# Altium schematic sheet Stepper_Outputs.SchDoc
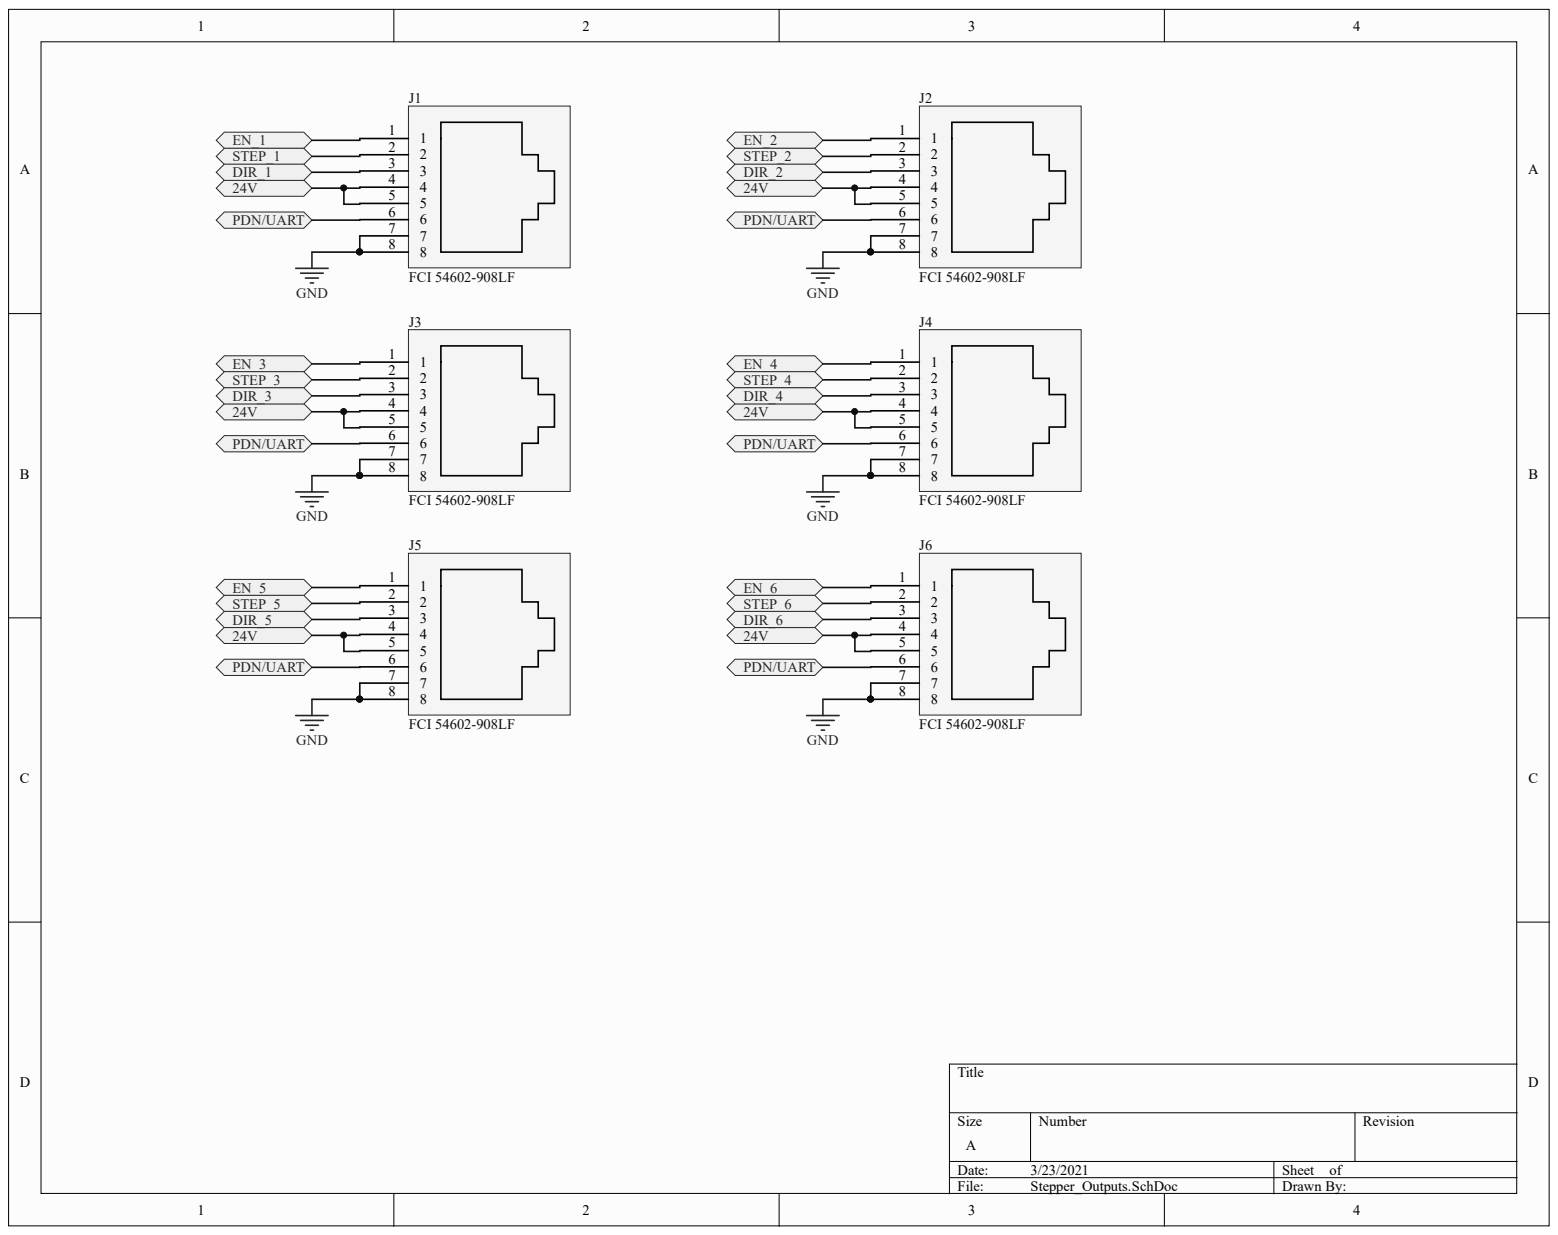
[diagram p0: Stepper_Outputs.SchDoc]

## Parts
J1  FCI 54602-908LF  Manufacturer Part Number: 54602-908LF  [PCB-kyusfhn5h9rxu8er00w0-1]
J2  FCI 54602-908LF  Manufacturer Part Number: 54602-908LF  [PCB-kyusfhn5h9rxu8er00w0-1]
J3  FCI 54602-908LF  Manufacturer Part Number: 54602-908LF  [PCB-kyusfhn5h9rxu8er00w0-1]
J4  FCI 54602-908LF  Manufacturer Part Number: 54602-908LF  [PCB-kyusfhn5h9rxu8er00w0-1]
J5  FCI 54602-908LF  Manufacturer Part Number: 54602-908LF  [PCB-kyusfhn5h9rxu8er00w0-1]
J6  FCI 54602-908LF  Manufacturer Part Number: 54602-908LF  [PCB-kyusfhn5h9rxu8er00w0-1]

## Ports
24V, DIR_1, DIR_2, DIR_3, DIR_4, DIR_5, DIR_6, EN_1, EN_2, EN_3, EN_4, EN_5, EN_6, PDN/UART, STEP_1, STEP_2, STEP_3, STEP_4, STEP_5, STEP_6

## Nets
GND: J1.7 J1.8 J2.7 J2.8 J3.7 J3.8 J4.7 J4.8 J5.7 J5.8 J6.7 J6.8
24V: J1.4 J1.5 J2.4 J2.5 J3.4 J3.5 J4.4 J4.5 J5.4 J5.5 J6.4 J6.5 ; port 24V
DIR_1: J1.3 ; port DIR_1
DIR_2: J2.3 ; port DIR_2
DIR_3: J3.3 ; port DIR_3
DIR_4: J4.3 ; port DIR_4
DIR_5: J5.3 ; port DIR_5
DIR_6: J6.3 ; port DIR_6
EN_1: J1.1 ; port EN_1
EN_2: J2.1 ; port EN_2
EN_3: J3.1 ; port EN_3
EN_4: J4.1 ; port EN_4
EN_5: J5.1 ; port EN_5
EN_6: J6.1 ; port EN_6
PDN/UART: J1.6 J2.6 J3.6 J4.6 J5.6 J6.6 ; port PDN/UART
STEP_1: J1.2 ; port STEP_1
STEP_2: J2.2 ; port STEP_2
STEP_3: J3.2 ; port STEP_3
STEP_4: J4.2 ; port STEP_4
STEP_5: J5.2 ; port STEP_5
STEP_6: J6.2 ; port STEP_6

## Library data of the parts
J1-J6 = CMP-1a15d1442034c5d2-8: Conn Modular Jack F 8 POS 2.54mm Solder RA Thru-Hole 8 Terminal 1 Port Box Cat 3; Gender: Male; Lead-Free Status: Lead Free; Number of Contacts: 8; Color: Black; Component Kind: Standard; Contact Material: Phosphor Bronze; Contact Plating: Gold; Library Name: CommunityVault; Mounting Style: Through Hole, PCB; OctopartID: 1a15d1442034c5d2; Octopart URL: http://octopart.com/54602-908lf-fci-39526215; REACH SVHC Compliance: No SVHC; RoHS: Compliant; Size-Height: 12.7 mm; Size-Length: 15.3 mm; Size-Width: 17.7 mm
